# Altium schematic sheet Interface_CTRL.SchDot
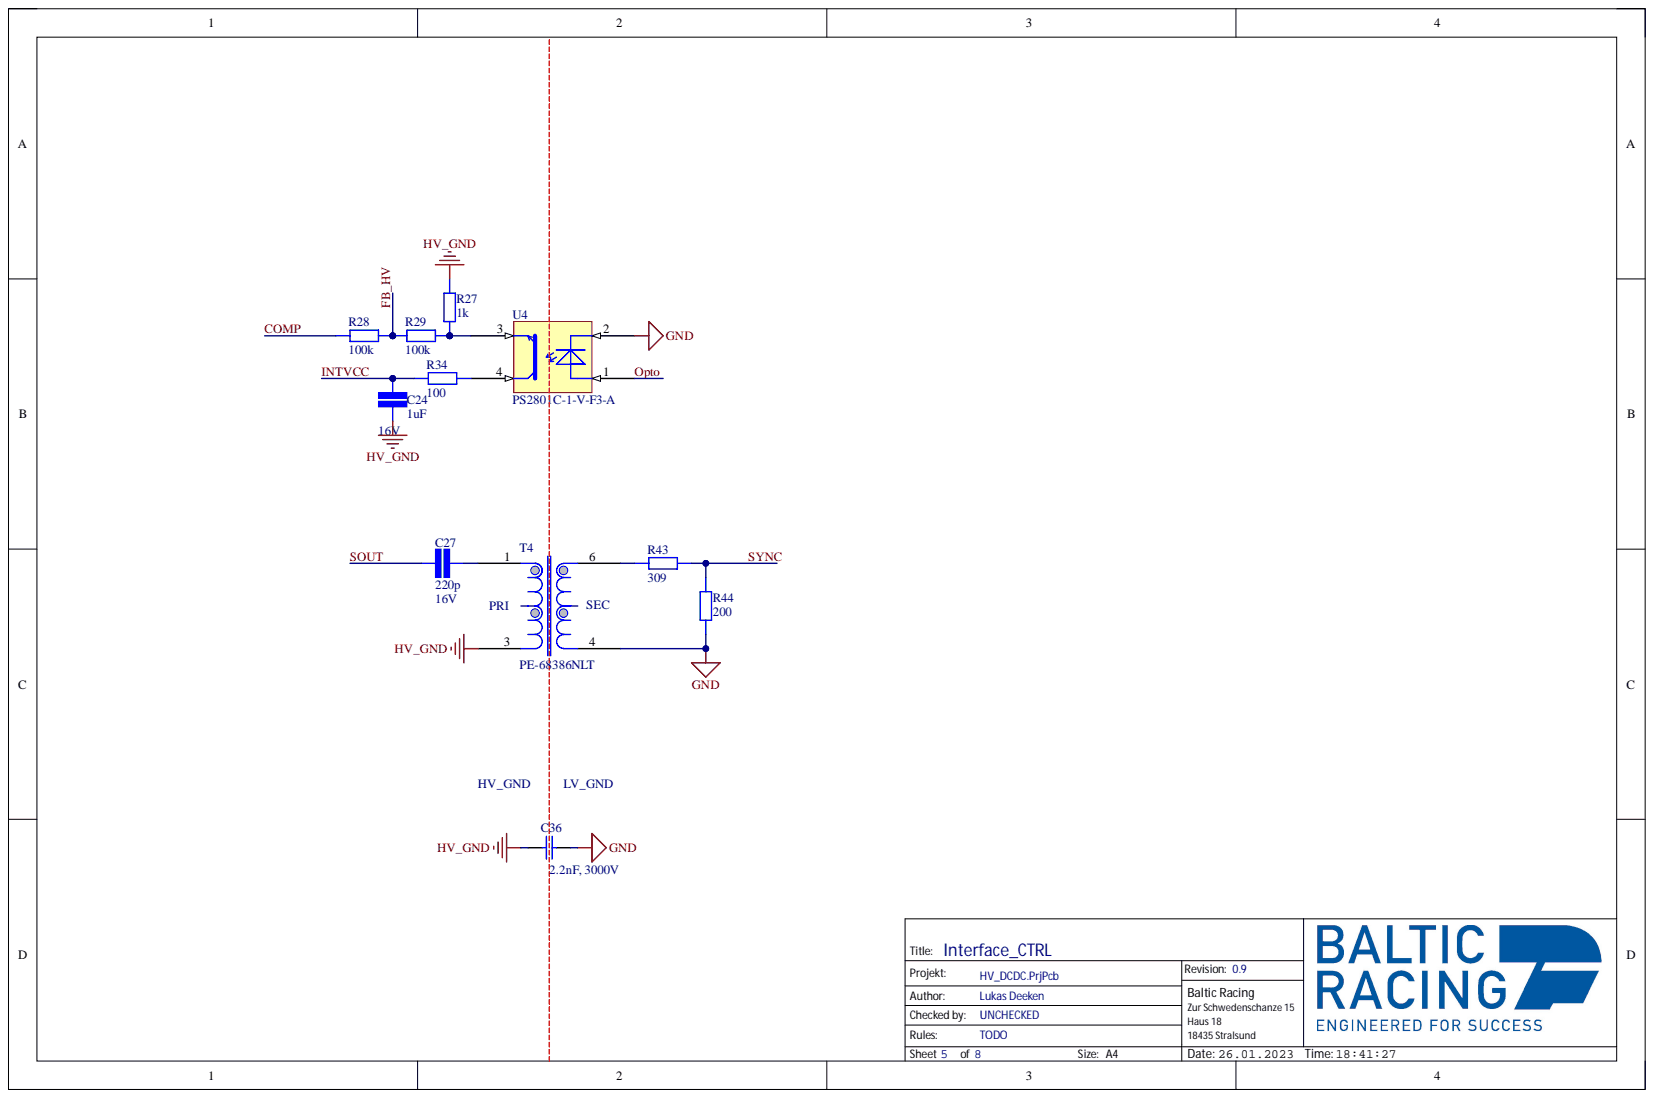
[diagram p0: Interface_CTRL.SchDot]

## Parts
C24  value 1uF  Voltage: 16V  [CAP 0603/1608]  (hidden comment: SMD Capacitor)
C27  value 220p  Voltage: 16V  [CAP 0603/1608]  (hidden comment: SMD Capacitor)
C36  2.2nF, 3000V  [WCAP-CSMH_1812_H2.5]
R27  value 1k  [STACKPOLE CSR0603]  (hidden comment: SMD Resistor)
R28  value 100k  [STACKPOLE CSR0603]  (hidden comment: SMD Resistor)
R29  value 100k  [STACKPOLE CSR0603]  (hidden comment: SMD Resistor)
R34  value 100  [STACKPOLE CSR0603]  (hidden comment: SMD Resistor)
R43  value 309  [STACKPOLE CSR0603]  (hidden comment: SMD Resistor)
R44  value 200  [STACKPOLE CSR0603]  (hidden comment: SMD Resistor)
T4  PE-68386NLT  [PE-68386NL Landing Pattern]
U4  PS2801C-1-V-F3-A  [SSOP127P700X200-4L]

## Nets
GND: C36.2 R44.1 T4.4 U4.2
HV_GND: C24.1 C36.1 R27.2 T4.3
COMP: R28.1
FB_HV: R28.2 R29.1
INTVCC: C24.2 R34.1
Opto: U4.1
SOUT: C27.1
SYNC: R43.2 R44.2
NetC27_2: C27.2 T4.1
NetR27_1: R27.1 R29.2 U4.3
NetR34_2: R34.2 U4.4
NetR43_1: R43.1 T4.6

## Library data of the parts
C24 C27 = SMD Capacitor: Capacitor with multiple Footprints; Component Kind: Standard; Library Name: Standardbauteile.IntLib
C36 = 885342211010: WCAP-CSMH Multilayer Ceramic Chip Capacitor, size 1812, X7R Class II, 2.2nF, 3000V; Manufacturer: Wurth Elektronik; Capacitance Tolerance: +/-10%; Dissipation Factor: 2.5%; Insulation Resistance: 10GOhm; Rated Voltage: 3000VDC; Technical Reference: X7R1812222KC00DFCT10000; Capacitance: 2.2nF; Ceramic Type: X7R Class II; Component Kind: Standard; ComponentLink1Description: Manufacturer; ComponentLink1URL: http://www.we-online.de; ComponentLink2Description: Datasheet; ComponentLink2URL: https://www.we-online.com/catalog/datasheet/885342211010.pdf; Height: 2.5mm; Library Name: Altium_WCAP-CSMH (rev20a).IntLib; Manufacturer Part Number: 885342211010; Mounting Technology: SMT; Operating Temperature: -55 to +125degC; Packaging: Tape and Reel; Published Date: May 2020; Size: 1812
R27-R29 R34 R43 R44 = SMD Resistor: Resistor with multiple footprints; Component Kind: Standard; Library Name: Standardbauteile.IntLib
T4 = PE-68386NLT: Pulse Transformer 1: 1 1500VDC 0.6Ohm Prim. DCR 0.6Ohm Sec. DCR 6 Terminal SMD Automotive; DC Resistance (DCR): 600mR; Shielding: Unshielded; Turns Ratio: 1:1; Category: Transformers; Component Kind: Standard; ComponentLink1Description: https://datasheet.ciiva.com/29632/spm2007-61-29632613.pdf?src-supplier=Digi-Key; ComponentLink1URL: https://datasheet.ciiva.com/29632/spm2007-61-29632613.pdf?src-supplier=Digi-Key; ComponentLink2Description: https://datasheet.ciiva.com/29632/+spm2007-61-29632614.pdf?src-supplier=Digi-Key; ComponentLink2URL: https://datasheet.ciiva.com/29632/+spm2007-61-29632614.pdf?src-supplier=Digi-Key; ComponentLink3Description: https://datasheet.ciiva.com/2939/+spm2007-61-2939805.pdf?src-supplier=Digi-Key; ComponentLink3URL: https://datasheet.ciiva.com/2939/+spm2007-61-2939805.pdf?src-supplier=Digi-Key; Depth: 8.64mm; Height: 2.5mm; Length: 6.73mm; Manufacturer: Pulse; Manufacturer Part Number: PE-68386NLT; Max Operating Temperature: 130°C; Min Operating Temperature: -40°C; Mount: Surface Mount; Number of Pins: 6; Package Quantity: 1500; Packaging: Tape and Reel; Pricing 1: 1=2,70000, 10=2,16000, 25=1,75520, 50=1,71000, 100=1,66500, 250=1,62000, 500=1,60650 (USD); Primary Impedance: 600mR; Radiation Hardening: No; RoHS: Yes; RoHS Compliant: Yes; Secondary Impedance: 600mR; Stock 1: 13846; Supplier 1: Digi-Key; Termination: SMD/SMT; Weight: 0.009877oz; Width: 6.7mm; Library Name: Integrated_LibraryLukas_New.IntLib
U4 = PS2801C-1-V-F3-A: Optocoupler DC-IN 1-CH Transistor DC-OUT 4-Pin SSOP Embossed T/R; Manufacturer: CEL; Collector Emitter Voltage (VCEO): 300mV; Input Type: DC; Number of Channels: 1; Output Current per Channel: 30mA; Output Voltage: 80V; Case/Package: SOIC; Category: Optocouplers; Collector-emitter Voltage-Max: 300mV; Component Kind: Standard; ComponentLink1Description: https://datasheet.ciiva.com/2088/ps2801c-1-ps2801c-4-2088034.pdf?src-supplier=Digi-Key; ComponentLink1URL: https://datasheet.ciiva.com/2088/ps2801c-1-ps2801c-4-2088034.pdf?src-supplier=Digi-Key; Lead Free: Lead Free; Manufacturer Part Number: PS2801C-1-V-F3-A; Mount: Surface Mount; Package Quantity: 3500; Packaging: Tape and Reel; RoHS: Yes; Series: NEPOC; Supplier 1: Digi-Key; Library Name: Integrated_LibraryLukas_New.IntLib
